# Altium schematic sheet HWSD-GH2GH V1.0.SchDoc
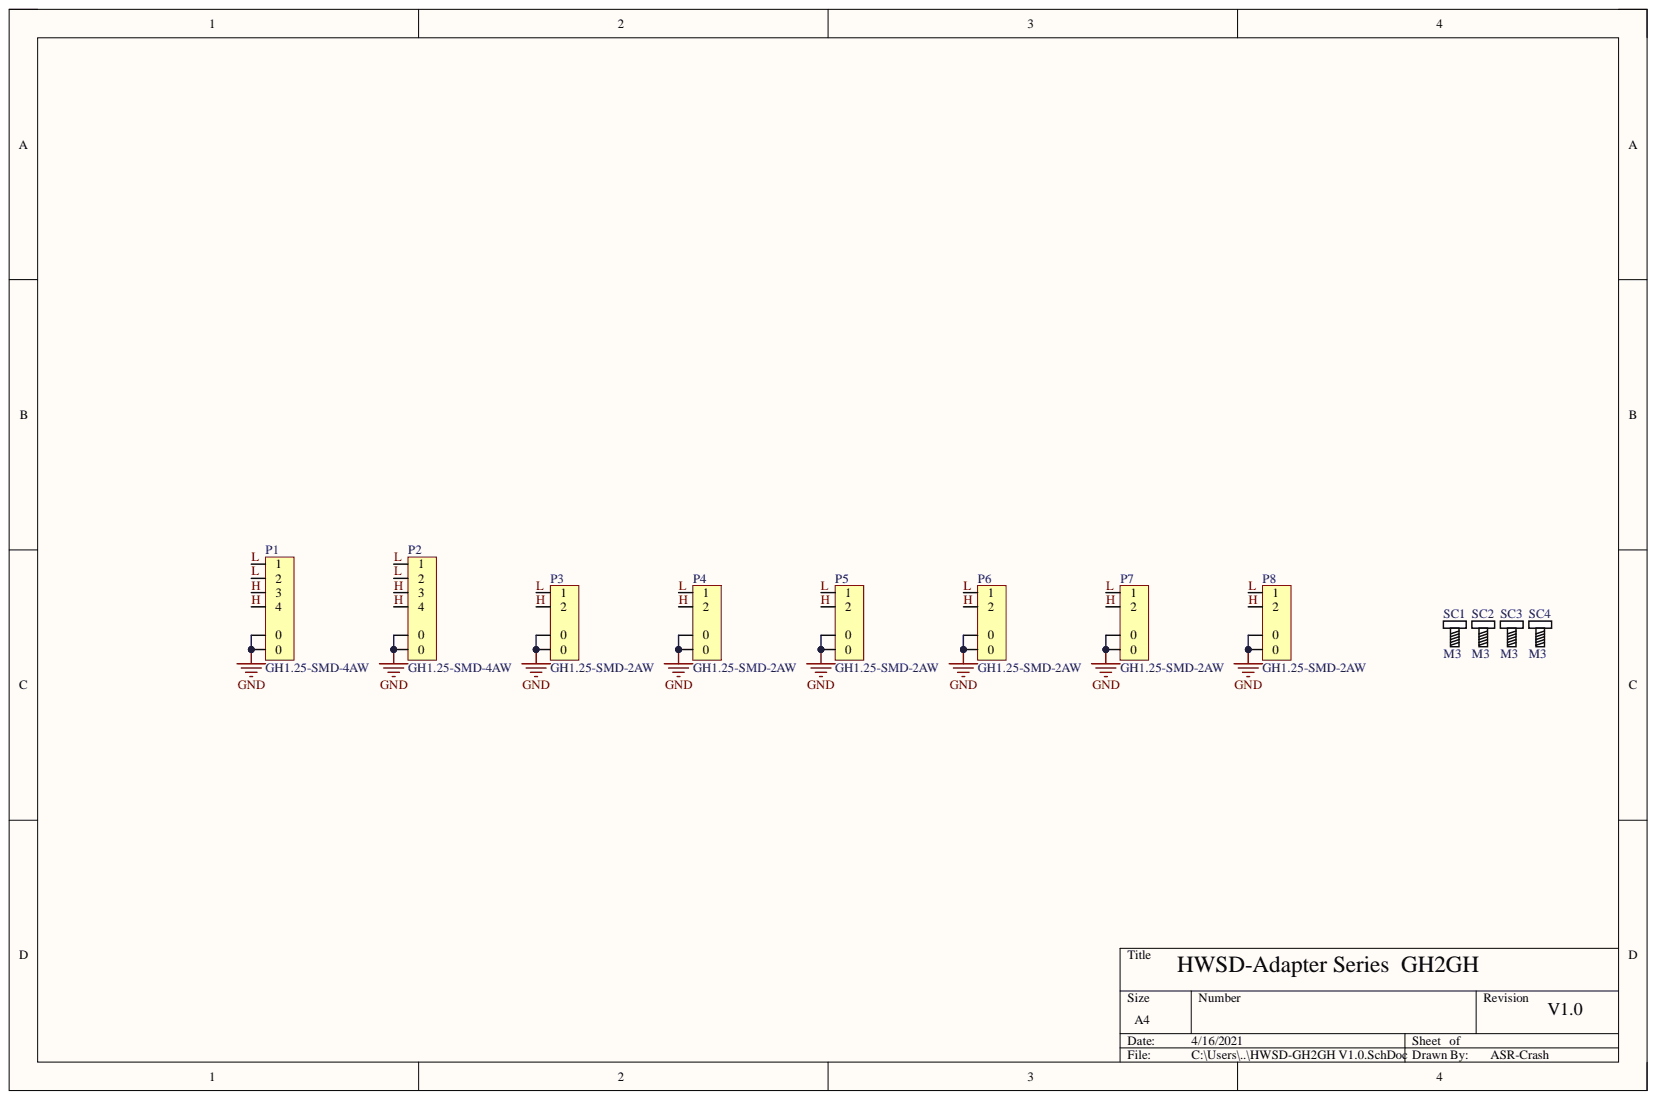
[diagram p0: HWSD-GH2GH V1.0.SchDoc]

## Parts
P1  GH1.25-SMD-4AW  [GH1.25_4 卧贴]
P2  GH1.25-SMD-4AW  [GH1.25_4 卧贴]
P3  GH1.25-SMD-2AW  [GH1.25_2 卧贴]
P4  GH1.25-SMD-2AW  [GH1.25_2 卧贴]
P5  GH1.25-SMD-2AW  [GH1.25_2 卧贴]
P6  GH1.25-SMD-2AW  [GH1.25_2 卧贴]
P7  GH1.25-SMD-2AW  [GH1.25_2 卧贴]
P8  GH1.25-SMD-2AW  [GH1.25_2 卧贴]
SC1  M3  [SCRREW_M3]
SC2  M3  [SCRREW_M3]
SC3  M3  [SCRREW_M3]
SC4  M3  [SCRREW_M3]

## Nets
GND: P1.0 P2.0 P3.0 P4.0 P5.0 P6.0 P7.0 P8.0
H: P1.3 P1.4 P2.3 P2.4 P3.2 P4.2 P5.2 P6.2 P7.2 P8.2
L: P1.1 P1.2 P2.1 P2.2 P3.1 P4.1 P5.1 P6.1 P7.1 P8.1

## Library data of the parts
P1 P2 = GH1.25-SMD-4AW: Interface; Component Kind: Standard; Library Name: Hardware Standardization Library.SchLib
P3-P8 = GH1.25-SMD-2AW: Interface; Component Kind: Standard; Library Name: Hardware Standardization Library.SchLib
SC1-SC4 = Screw_M3: Screw; Component Kind: Standard; Library Name: Hardware Standardization Library.SchLib
